# Altium schematic sheet audio.SchDoc(audio)
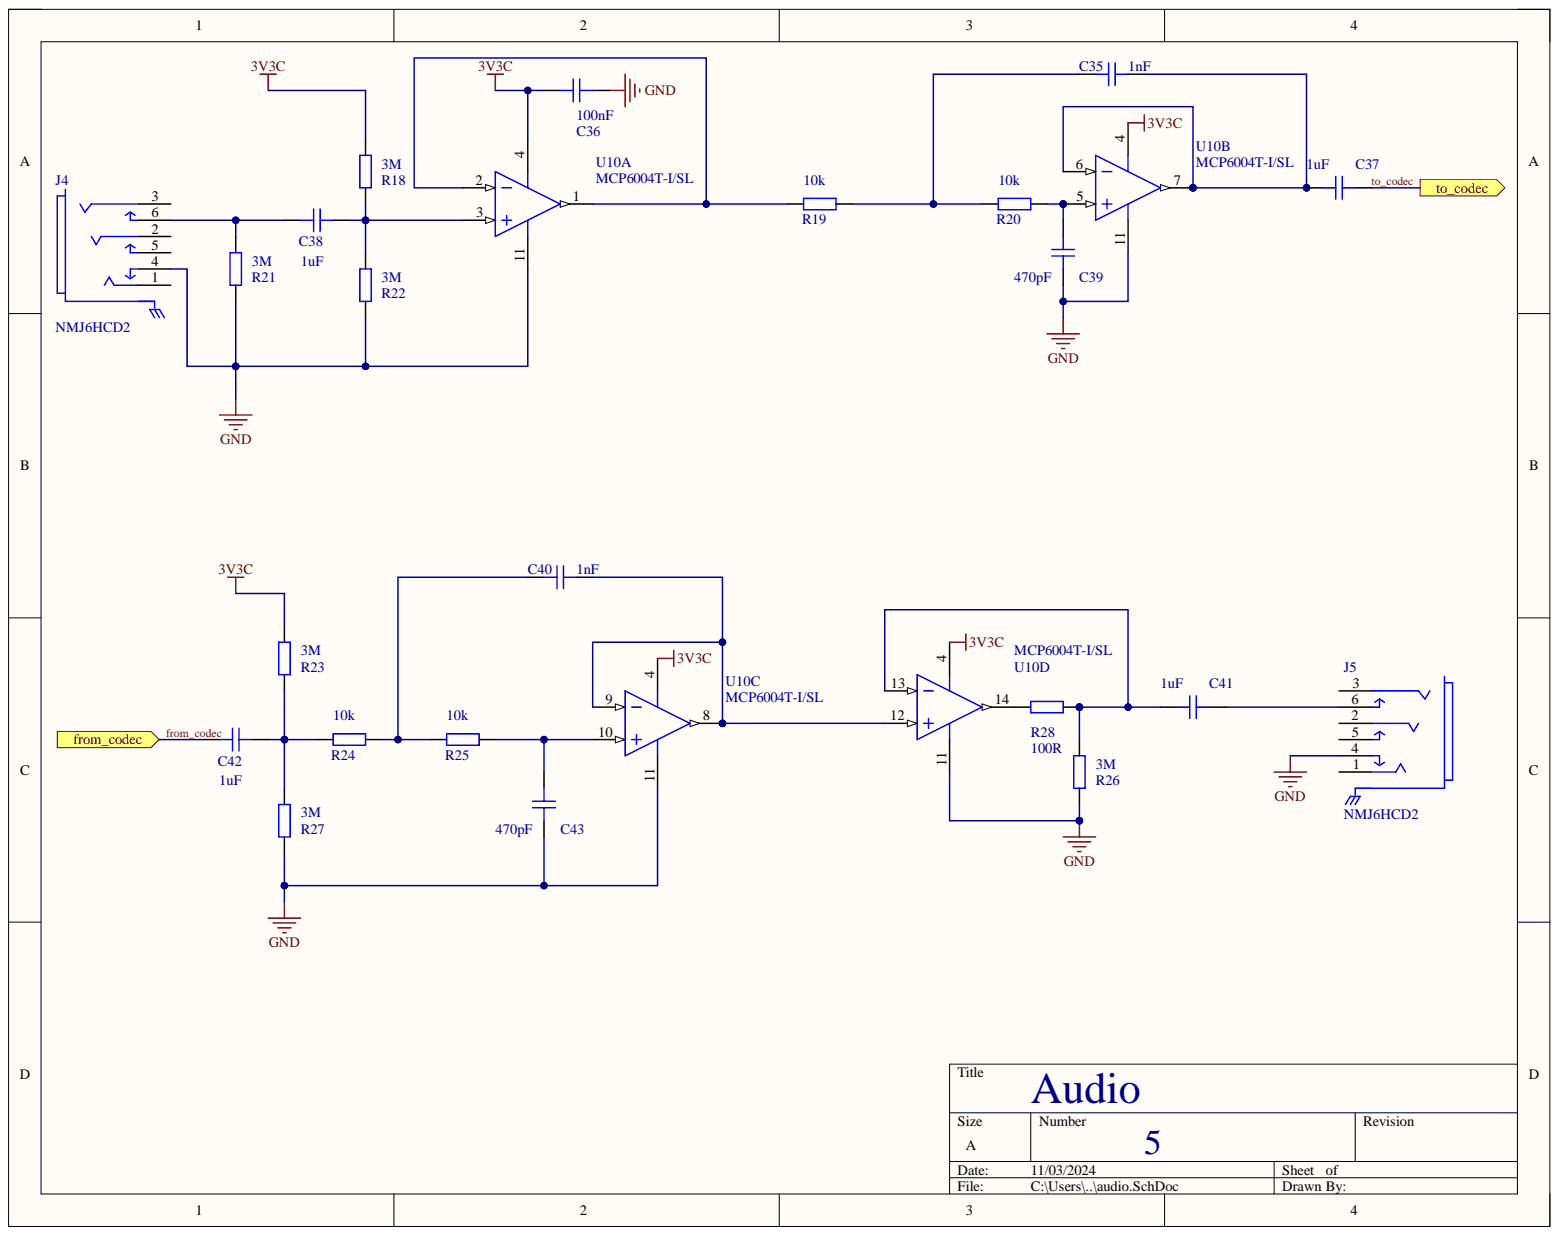
[diagram p0: audio.SchDoc]

## Parts
C35  1nF  [CAP_0805]
C36  100nF  [CAP_0805]
C37  1uF  [CAP_0805]
C38  1uF  [CAP_0805]
C39  470pF  [CAP_0805]
C40  1nF  [CAP_0805]
C41  1uF  [CAP_0805]
C42  1uF  [CAP_0805]
C43  470pF  [CAP_0805]
J4  NMJ6HCD2  [FP-NMJ6HCD2-MFG]
J5  NMJ6HCD2  [FP-NMJ6HCD2-MFG]
R18  3M  [RES_0805]
R19  10k  [RES_0805]
R20  10k  [RES_0805]
R21  3M  [RES_0805]
R22  3M  [RES_0805]
R23  3M  [RES_0805]
R24  10k  [RES_0805]
R25  10k  [RES_0805]
R26  3M  [RES_0805]
R27  3M  [RES_0805]
R28  100R  [RES_0805]
U10  MCP6004T-I/SL  [SOIC-SL14_M]  (parts A,B,C,D)

## Ports
from_codec, to_codec

## Nets
3V3C: C36.1 R18.2 R23.1 U10.4
GND: C36.2 C39.1 C43.1 J4.4 J5.4 R21.1 R22.1 R26.1 R27.2 U10.11
from_codec: C42.1 ; port from_codec
to_codec: C37.1 ; port to_codec
NetC35_1: C35.1 R19.1 R20.2
NetC35_2: C35.2 C37.2 U10.6 U10.7
NetC38_1: C38.1 J4.6 R21.2
NetC38_2: C38.2 R18.1 R22.2 U10.3
NetC39_2: C39.2 R20.1 U10.5
NetC40_1: C40.1 R24.2 R25.1
NetC40_2: C40.2 U10.8 U10.9 U10.12
NetC41_1: C41.1 J5.6
NetC41_2: C41.2 R26.2 R28.2 U10.13
NetC42_2: C42.2 R23.2 R24.1 R27.1
NetC43_2: C43.2 R25.2 U10.10
NetR19_2: R19.2 U10.1 U10.2
NetR28_1: R28.1 U10.14

Not connected: J4 1 2 3 5; J5 1 2 3 5

## Library data of the parts
C35-C43 = CMP-001-00086-1: Capacitor SMD 0805; Component Kind: Standard; Design Item ID: CAP_0805; Library Name: Projects; Source: Schematic-Lib-PhilsLab.SchLib
J4 J5 = CMP-78736-000004-1: Conn 1/4" Stereo Jack F 2 POS Solder ST Thru-Hole 6 Terminal 1 Port; Gender: Female; Max Current Rating: 3A; Number of Contacts: 2; Number of Ports: 1; Number of Terminals/Pins: 6; Voltage Rating: 50V; Color: Black; Component Kind: Standard; Contact Material: Chrome; Contact Plating: Silver; Depth: 18.2mm; Housing Material: Polyamide; Library Name: Altium Content Vault; Max Operating Temperature: 70°C; Min Operating Temperature: -25°C; Mount: Through Hole; Number of Pins: 6; Orientation: Horizontal; Package Quantity: 200; RoHS Compliant: Yes; Series: M; Termination: Through Hole; Weight: 0.282192oz
R18-R28 = CMP-009-00180-1: Resistor SMD 0805; Component Kind: Standard; Design Item ID: RES_0805; Library Name: Projects; Source: Schematic-Lib-PhilsLab.SchLib
U10 = CMP-0187-00023-3: None; Amplifier Type: GENERAL PURPOSE; Bandwidth: 1MHz; Common Mode Rejection Ratio: 60dB; Input Bias Current: 1pA; Input Offset Voltage (Vos): 4.5mV; Max Frequency: 1kHz; Max Supply Voltage: 6V; Min Supply Voltage: 1.8V; Nominal Supply Current: 100uA; Number of Amplifiers: 4; Operating Supply Voltage: 5.5V; Output Current: 23mA; Power Supply Rejection Ratio (PSRR): 86dB; Slew Rate: 0.6V/µs; Voltage Gain: 112dB; Case/Package: SOIC; Component Kind: Standard; Gain Bandwidth Product: 1MHz; Height: 1.5mm; Input Offset Voltage-Max: 4.5mV; Lead Free: Lead Free; Length: 8.65mm; Library Name: Altium Content Vault; Max Operating Temperature: 85°C; Min Operating Temperature: -40°C; Mount: Surface Mount; Number of Channels: 4; Number of Circuits: 4; Number of Elements: 4; Number of Pins: 14; Operating Supply Current: 100uA; Output Current per Channel: 23mA; Package Quantity: 2600; Packaging: Tape and Reel; Radiation Hardening: No; REACH SVHC: No SVHC; RoHS Compliant: Yes; Series: -; Slew Rate-Nom: 0.6V/µs; Supply Current: 100uA; Technology: CMOS; Unity Gain Bandwidth Product: 1MHz; Width: 3.9mm
